# Altium schematic sheet CH340C.SchDoc
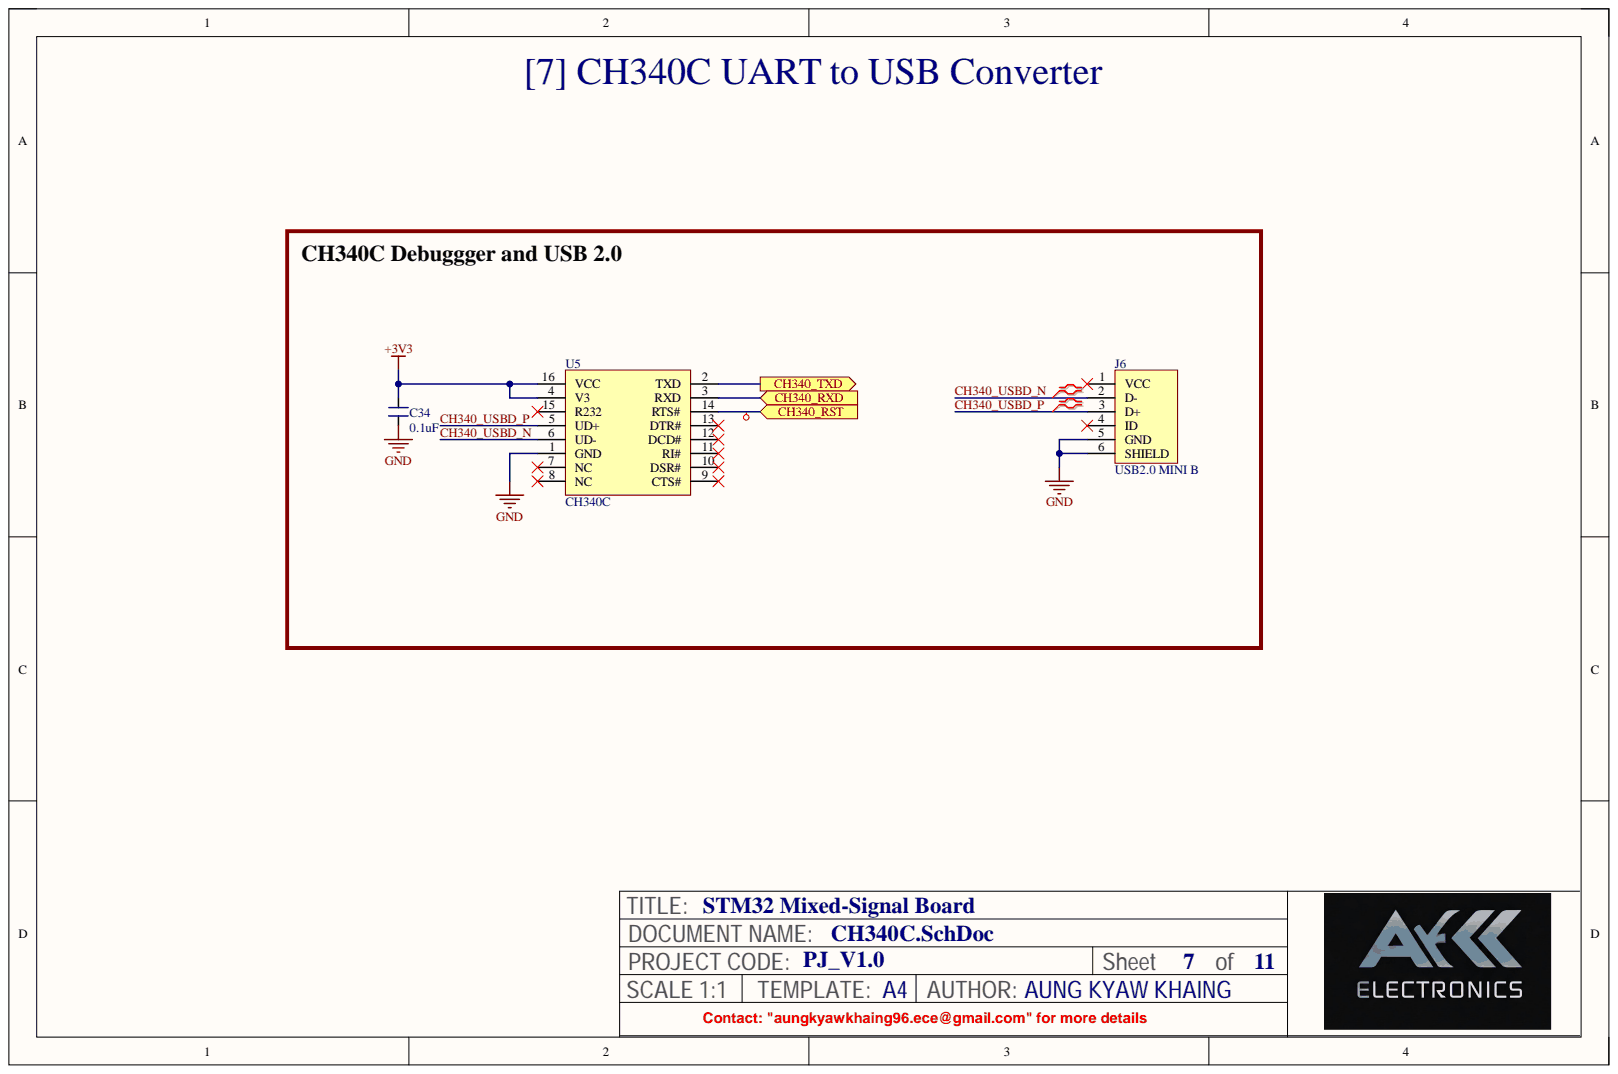
[diagram p0: CH340C.SchDoc]

## Parts
C34  0.1uF  [CAP_0805]
J6  USB2.0 MINI B  [CON_690-005-299-043]
U5  CH340C  [SOIC16_50_284_25X90_SMT]

## Ports
CH340_RST, CH340_RXD, CH340_TXD

## Nets
+3V3: C34.2 U5.4(V3) U5.16(VCC)
GND: C34.1 J6.5(GND) J6.6(SHIELD) U5.1(GND)
CH340_RST: U5.14(RTS#) ; port CH340_RST
CH340_RXD: U5.3(RXD) ; port CH340_RXD
CH340_TXD: U5.2(TXD) ; port CH340_TXD
CH340_USBD_N: J6.2(D-) U5.6(UD-)
CH340_USBD_P: J6.3(D+) U5.5(UD+)

Not connected: J6 1(VCC) 4(ID); U5 7(NC) 8(NC) 9(CTS#) 10(DSR#) 11(RI#) 12(DCD#) 13(DTR#) 15(R232)

## Library data of the parts
C34 = CAP_0.1uF-50V-5%-0805: 0.1µF ±5% 50V Ceramic Capacitor X7R 0805 (2012 Metric); Alternate Part Number-1: 0805B104M500; Manufacturer: AVX Corporation; Manufacturer Part Number: 08055C104JAT4A; Case/Package: 0805; Component Kind: Standard; Library Name: ESTEEMPCBLIB.IntLib; Supplier Part Number-1: 0805B104J500CT; Supplier-1: SIRICOM
J6 = CON_USB2.0-MINIB-SMD: USB - mini B USB 2.0 Receptacle Connector 5 Position Surface Mount, Right Angle; Alternate Part Number-1: NA; Manufacturer: EDAC; Manufacturer Part Number: 690-005-299-043; Case/Package: USB-MINI-B; Component Kind: Standard; Library Name: ESTEEMPCBLIB.IntLib; Supplier Part Number-1: C105424; Supplier-1: LCSC
U5 = IC_CH340C: USB Bridge, USB to UART USB 2.0 UART Interface; Alternate Part Number-1: NA; Manufacturer: Olimex; Case/Package: SOIC16; Component Kind: Standard; Library Name: ESTEEMPCBLIB.IntLib; Manufacturer Part Number: CH340C; Supplier Part Number-1: C84681; Supplier-1: LCSC
